# Altium schematic sheet Power.SchDot(Power)
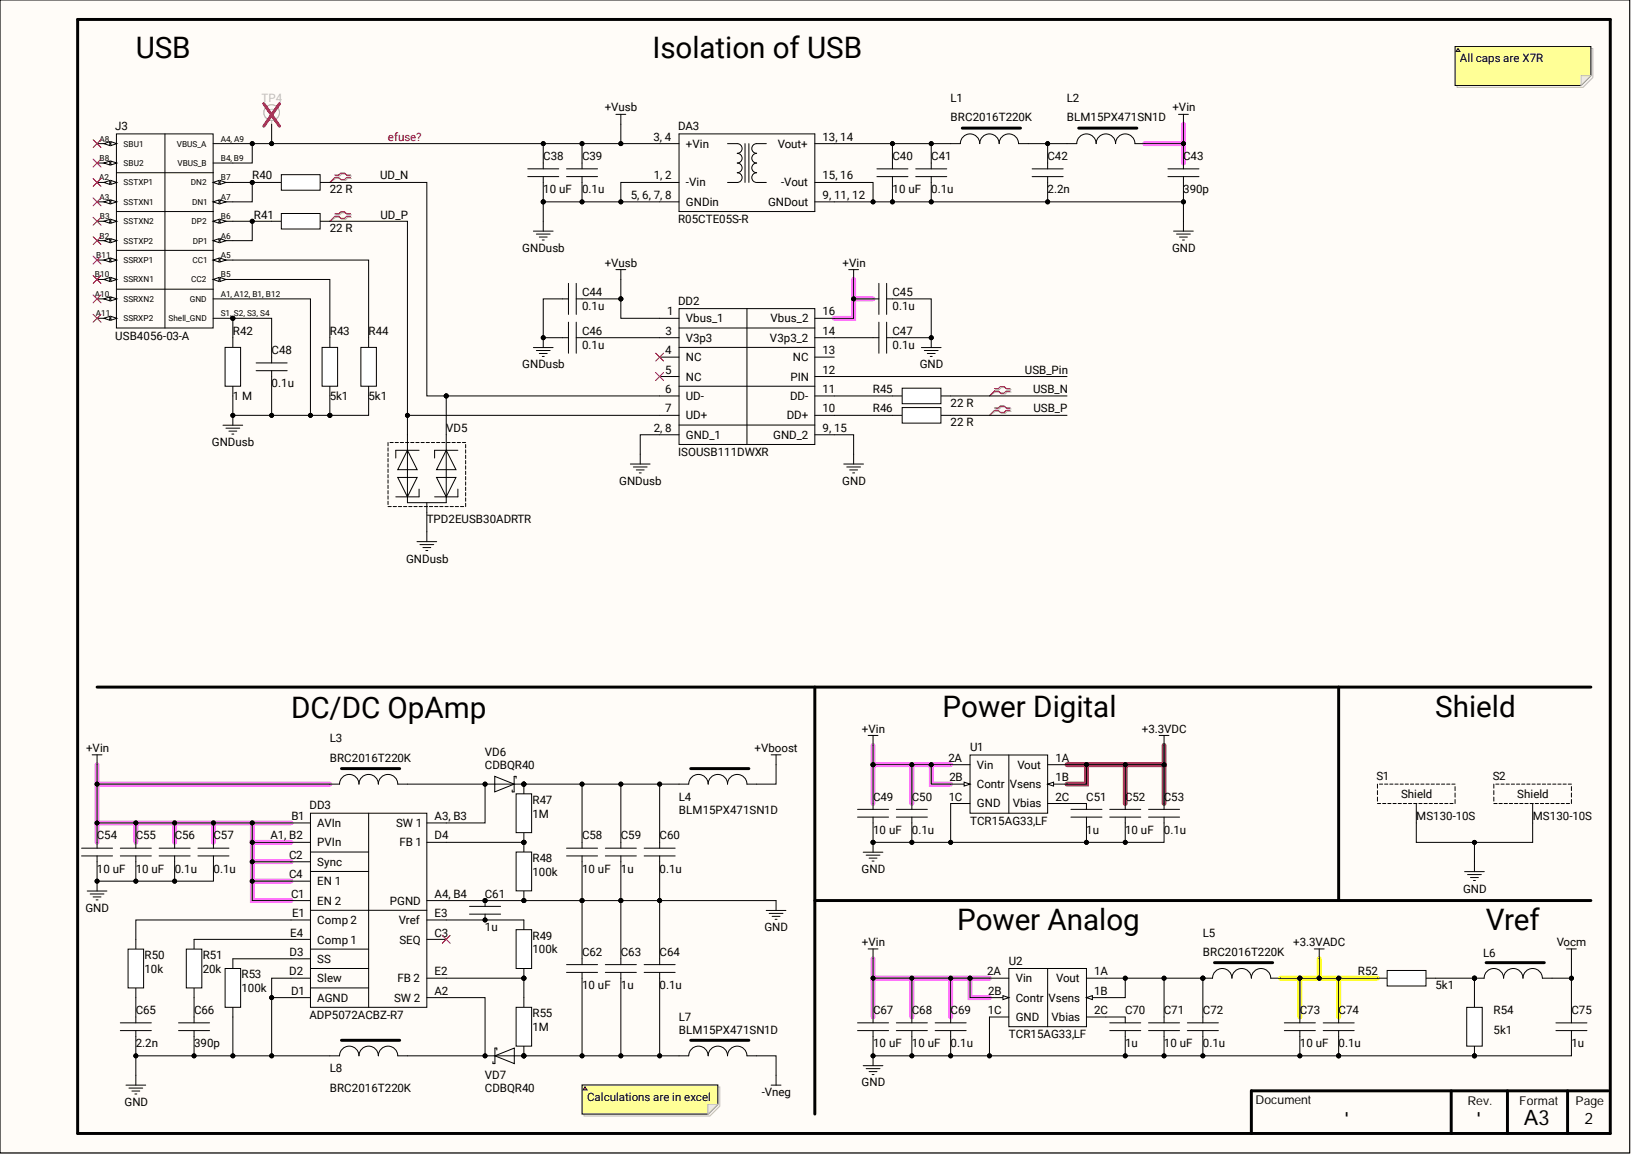
[diagram p0: Power.SchDot(Power)]

## Parts
C38  10 uF  [C0402]
C39  0.1u  [C0402]
C40  10 uF  [C0402]
C41  0.1u  [C0402]
C42  2.2n  [C0402]
C43  390p  [C0402]
C44  0.1u  [C0402]
C45  0.1u  [C0402]
C46  0.1u  [C0402]
C47  0.1u  [C0402]
C48  0.1u  [C0402]
C49  10 uF  [C0402]
C50  0.1u  [C0402]
C51  1u  [C0402]
C52  10 uF  [C0402]
C53  0.1u  [C0402]
C54  10 uF  [C0402]
C55  10 uF  [C0402]
C56  0.1u  [C0402]
C57  0.1u  [C0402]
C58  10 uF  [C0402]
C59  1u  [C0402]
C60  0.1u  [C0402]
C61  1u  [C0402]
C62  10 uF  [C0402]
C63  1u  [C0402]
C64  0.1u  [C0402]
C65  2.2n  [C0402]
C66  390p  [C0402]
C67  10 uF  [C0402]
C68  10 uF  [C0402]
C69  0.1u  [C0402]
C70  1u  [C0402]
C71  10 uF  [C0402]
C72  0.1u  [C0402]
C73  10 uF  [C0402]
C74  0.1u  [C0402]
C75  1u  [C0402]
DA3  R05CTE05S-R  [SOIC-16]
DD2  ISOUSB111DWXR  [SSOP-16]
DD3  ADP5072ACBZ-R7  [WLCSP-20]
J3  USB4056-03-A  [USB 3.2 Gen 2]
L1  BRC2016T220K  [L0603]
L2  BLM15PX471SN1D  [R0402]
L3  BRC2016T220K  [L0603]
L4  BLM15PX471SN1D  [R0402]
L5  BRC2016T220K  [L0603]
L6  BLM15PX471SN1D  [R0402]
L7  BLM15PX471SN1D  [R0402]
L8  BRC2016T220K  [L0603]
R40  22 R  [R0402]
R41  22 R  [R0402]
R42  1 M  [R0402]
R43  5k1  [R0402]
R44  5k1  [R0402]
R45  22 R  [R0402]
R46  22 R  [R0402]
R47  1M  [R0402]
R48  100k  [R0402]
R49  100k  [R0402]
R50  10k  [R0402]
R51  20k  [R0402]
R52  5k1  [R0402]
R53  100k  [R0402]
R54  5k1  [R0402]
R55  1M  [R0402]
S1  MS130-10S  [13_13_5mm]
S2  MS130-10S  [13_13_5mm]
TP4  5117  [36-5117-ND]  (value not drawn)
U1  TCR15AG33,LF  [WCSP-6F-6]
U2  TCR15AG33,LF  [WCSP-6F-6]
VD5  TPD2EUSB30ADRTR  [SOT-9X3]
VD6  CDBQR40  [0402_VD]
VD7  CDBQR40  [0402_VD]

## Nets
+3.3VADC: C73.1 C74.1 L5.2 R52.1
+3.3VDC: C52.1 C53.1 U1.1A(Vout) U1.1B(Vsens)
+Vboost: L4.2
+Vin: C43.1 C45.1 C49.1 C50.1 C54.1 C55.1 C56.1 C57.1 C67.1 C68.1 C69.1 DD2.16(Vbus_2) DD3.A1, B2(PVIn) DD3.B1(AVIn) DD3.C1(EN_2) DD3.C2(Sync) DD3.C4(EN_1) L2.2 L3.1 U1.2A(Vin) U1.2B(Contr) U2.2A(Vin) U2.2B(Contr)
+Vusb: C38.1 C39.1 C44.1 DA3.3, 4(+Vin) DD2.1(Vbus_1) J3.A4, A9(VBUS_A) J3.B4, B9(VBUS_B) TP4.1
-Vneg: L7.2
GND: C40.2 C41.2 C42.2 C43.2 C45.2 C47.1 C49.2 C50.2 C51.1 C52.2 C53.2 C54.2 C55.2 C56.2 C57.2 C58.2 C59.1 C60.2 C61.2 C62.1 C63.2 C64.1 C65.2 C66.2 C67.2 C68.2 C69.2 C70.1 C71.2 C72.2 C73.2 C74.2 C75.1 DA3.9, 11, 12(GNDout) DA3.15, 16(-Vout) DD2.9, 15(GND_2) DD3.A4, B4(PGND) DD3.D1(AGND) DD3.D2(Slew) L8.1 R48.1 R53.1 R54.2 S1.1 S2.1 U1.1C(GND) U2.1C(GND)
GNDusb: C38.2 C39.2 C44.2 C46.2 C48.2 DA3.1, 2(-Vin) DA3.5, 6, 7, 8(GNDin) DD2.2, 8(GND_1) J3.A1, A12, B1, B12(GND) R42.2 R43.2 R44.2 VD5.3
Vocm: C75.2 L6.2
UD_N: DD2.6(UD-) R40.2 VD5.1
UD_P: DD2.7(UD+) R41.2 VD5.2
USB_N: R45.2
USB_P: R46.2
USB_Pin: DD2.12(PIN)
NetC40_1: C40.1 C41.1 DA3.13, 14(Vout+) L1.1
NetC42_1: C42.1 L1.2 L2.1
NetC46_1: C46.1 DD2.3(V3p3)
NetC47_2: C47.2 DD2.14(V3p3_2)
NetC48_1: C48.1 J3.S1, S2, S3, S4(Shell_GND) R42.1
NetC51_2: C51.2 U1.2C(Vbias)
NetC58_1: C58.1 C59.2 C60.1 L4.1 R47.1 VD6.2
NetC61_1: C61.1 DD3.E3(Vref) R49.2
NetC62_2: C62.2 C63.1 C64.2 L7.1 R55.1 VD7.1
NetC65_1: C65.1 R50.1
NetC66_1: C66.1 R51.1
NetC70_2: C70.2 U2.2C(Vbias)
NetC71_1: C71.1 C72.1 L5.1 U2.1A(Vout) U2.1B(Vsens)
NetDD2_10: DD2.10(DD+) R46.1
NetDD2_11: DD2.11(DD-) R45.1
NetDD3_A2: DD3.A2(SW_2) L8.2 VD7.2
NetDD3_A3, B3: DD3.A3, B3(SW_1) L3.2 VD6.1
NetDD3_D3: DD3.D3(SS) R53.2
NetDD3_D4: DD3.D4(FB_1) R47.2 R48.2
NetDD3_E1: DD3.E1(Comp_2) R50.2
NetDD3_E2: DD3.E2(FB_2) R49.1 R55.2
NetDD3_E4: DD3.E4(Comp_1) R51.2
NetJ3_A5: J3.A5(CC1) R44.1
NetJ3_A6: J3.A6(DP1) J3.B6(DP2) R41.1
NetJ3_A7: J3.A7(DN1) J3.B7(DN2) R40.1
NetJ3_B5: J3.B5(CC2) R43.1
NetL6_1: L6.1 R52.2 R54.1

Not connected: DD2 4(NC) 5(NC) 13(NC); DD3 C3(SEQ); J3 A2(SSTXP1) A3(SSTXN1) A8(SBU1) A10(SSRXN2) A11(SSRXP2) B2(SSTXP2) B3(SSTXN2) B8(SBU2) B10(SSRXN1) B11(SSRXP1)

## Library data of the parts
C38 C40 C49 C52 C54 C55 C58 C62 C67 C68 C71 C73 = MLCC: Multilayer Ceramic Capacitors MLCC - SMD/SMT 10 uF 10 VDC 20% 0402 X5R; Manufacturer: Murata; P/N: GRM155R61A106ME11D; Case: 0402; Component Kind: Standard; Component Type: MLCC; ComponentLink1Description: Link; ComponentLink2Description: Datasheet; Value: 10 uF; Library Name: Scheet.SchLib
  C38 C40 C54 C55 C58 C62: ComponentLink1URL: https://eu.mouser.com/ProductDetail/Murata-Electronics/GRT155C81A475ME13D?qs=ufv2HEpFn%252BRTWI1%252Bv%2F3NwA%3D%3D; ComponentLink2URL: https://eu.mouser.com/datasheet/2/281/1/GRT155C81A475ME13_01A-3135426.pdf
C39 C41-C48 C50 C53 C56 C57 C60 C64-C66 C69 C72 C74 = MLCC: Multilayer Ceramic Capacitors MLCC - SMD/SMT 0.1 uF 50 VDC 20% 0402 X6S; Manufacturer: Murata; P/N: GRM155C81H104ME14D; Case: 0402; Component Kind: Standard; Component Type: MLCC; ComponentLink1Description: Link; ComponentLink2Description: Datasheet; Library Name: Scheet.SchLib
  C39 C44 C46-C48: ComponentLink1URL: https://eu.mouser.com/ProductDetail/Murata-Electronics/GRM155C81H104ME14D?qs=IPQhFcwEUOignsDjW4gFkg%3D%3D; ComponentLink2URL: https://eu.mouser.com/datasheet/2/281/1/GRM155C81H104ME14_01A-1983691.pdf; Value: 0.1u
  C41 C45 C50 C53 C56 C57 C60 C64 C69 C72 C74: Value: 0.1u
  C42 C65: Value: 2.2n
  C43 C66: Value: 390p
C51 C59 C61 C63 C70 C75 = MLCC: Multilayer Ceramic Capacitors MLCC - SMD/SMT 1 uF 10 VDC 20% 0402 X7S AEC-Q200; Manufacturer: Murata; P/N: GCM155C71A105ME38D; Case: 0402; Component Kind: Standard; Component Type: MLCC; ComponentLink1Description: Link; ComponentLink1URL: https://eu.mouser.com/ProductDetail/Murata-Electronics/GCM155C71A105ME38D?qs=QzBtWTOodeV5ddl%2FvWs3LA%3D%3D; ComponentLink2Description: Datasheet; ComponentLink2URL: https://eu.mouser.com/datasheet/2/281/1/GCM155C71A105ME38_01A-3142248.pdf; Value: 1u; Library Name: Scheet.SchLib
DA3 = R05CTE05S-R: Isolated DC/DC Converters - SMD 1W 4.5-5.5Vin 5.0Vout 200mA Reel; Manufacturer: RECOM; Value: 1 W, 5V; Case: SOIC-16; Component Kind: Standard; Component Type: Isolated DC/DC Converters - SMD; ComponentLink1Description: Datasheet; ComponentLink1URL: https://eu.mouser.com/datasheet/2/468/RxxCTExxS-3179767.pdf; ComponentLink2Description: Link; ComponentLink2URL: https://eu.mouser.com/ProductDetail/RECOM-Power/R05CTE05S-R?qs=7D1LtPJG0i0SUCKLd%252BnSSQ%3D%3D; P/N: R05CTE05S-R; Library Name: Scheet.SchLib
DD2 = ISOUSB111DWXR: USB Interface IC Low-emission isolated USB repeater supporting low and full speed 16-SSOP -40 to 125; Manufacturer: Texas Instruments; Value: USB 2.0; Case: SSOP-16; Component Kind: Standard; Component Type: USB Interface IC; ComponentLink1Description: Datasheet; ComponentLink1URL: https://www.ti.com/lit/ds/symlink/isousb111.pdf?ts=1708034758891&ref_url=https%253A%252F%252Fwww.ti.com%252Fproduct%252FISOUSB111; ComponentLink2Description: Link; ComponentLink2URL: https://eu.mouser.com/ProductDetail/Texas-Instruments/ISOUSB111DWXR?qs=Y0Uzf4wQF3msEozH7EwD3g%3D%3D; P/N: ISOUSB111DWXR; Library Name: Scheet.SchLib
DD3 = ADP5072ACBZ-R7: Switching Voltage Regulators 1 A/0.6 A DC-to-DC Switching Regulator with Independent Positive and Negative Outputs; Manufacturer: Analog Devices Inc.; Value: - 30 V to 35 V; Case: WLCSP-20; Component Kind: Standard; Component Type: Switching Voltage Regulators; ComponentLink1Description: Datasheet; ComponentLink1URL: https://eu.mouser.com/datasheet/2/609/adp5072-3121366.pdf; ComponentLink2Description: Link; ComponentLink2URL: https://eu.mouser.com/ProductDetail/Analog-Devices/ADP5072ACBZ-R7?qs=w%2Fv1CP2dgqoekXcyqSGVnQ%3D%3D; P/N: ADP5072ACBZ-R7; Library Name: Scheet.SchLib
J3 = USB4056-03-A: USB Connectors USB C 3.2 Rec, Horz, Hybrid PCB Top Mount, T+R; Manufacturer: Global Connector Technology (GCT); Value: 3.2; Case: Type C 24 pin; Component Kind: Standard; Component Type: Type C 24 pin; ComponentLink1Description: Datasheet; ComponentLink1URL: https://eu.mouser.com/datasheet/2/837/GCT_06232023_USB4056-3223375.pdf; ComponentLink2Description: Link; ComponentLink2URL: https://eu.mouser.com/ProductDetail/GCT/USB4056-03-A?qs=QNEnbhJQKvbZ7hZzgFEugA%3D%3D; P/N: USB4056-03-A; Library Name: Scheet.SchLib
L1 L3 L5 L8 = LQM18DZ470M70L: Power Inductors - SMD 0603 47uH 20% 180mA 3.32ohm AEC-Q200; Case: 0603; Component Kind: Standard; Component Type: Power Inductors - SMD; ComponentLink1Description: Datasheet; ComponentLink1URL: https://eu.mouser.com/datasheet/2/281/1/JELF243B_9128-3158890.pdf; ComponentLink2Description: Link; ComponentLink2URL: https://eu.mouser.com/ProductDetail/Murata-Electronics/LQM18DZ470M70L?qs=aP1CjGhiNiGa4GC35xm0xg%3D%3D; P/N: BRC2016T220K; Library Name: Scheet.SchLib
L2 L4 L6 L7 = BLM15PX471SN1D: Ferrite Beads 470 OHM 25% Alternate Sizing Guide Below; Manufacturer: Murata; Value: 470 Ohms, 1A; Case: 0402; Component Kind: Standard; Component Type: Ferrite Beads; ComponentLink1Description: Datasheet; ComponentLink1URL: https://eu.mouser.com/datasheet/2/281/ENFA0018-1915754.pdf; ComponentLink2Description: Link; ComponentLink2URL: https://eu.mouser.com/ProductDetail/Murata-Electronics/BLM15PX471SN1D?qs=eTNEoJdcnEJgxrnv%252BsVZMw%3D%3D; P/N: BLM15PX471SN1D; Library Name: Scheet.SchLib
R40 R41 R45 R46 = SMD Resistor: Res SMD; Manufacturer: Panasonic; P/N: ERJ-2GEJ220X; Case: 0402; Component Kind: Standard; ComponentLink1Description: Datasheet; ComponentLink2Description: Link; Value: 22 R; Library Name: Scheet.SchLib
  R40 R45: ComponentLink1URL: https://eu.mouser.com/datasheet/2/315/AOA0000C301-1488782.pdf; ComponentLink2URL: https://eu.mouser.com/ProductDetail/Panasonic/ERJ-2GEJ220X?qs=sGAEpiMZZMvdGkrng054t8ugkoYZivkgE05%252BFXPHW90%3D
R42 = SMD Resistor: Thick Film Resistors 0402 5% 1.0Mohm Anti-Sulfur AEC-Q200; Manufacturer: Panasonic; P/N: ERJ-U02J105X; Case: 0402; Component Kind: Standard; ComponentLink1Description: Datasheet; ComponentLink1URL: https://eu.mouser.com/datasheet/2/315/AOA0000C334-1314047.pdf; ComponentLink2Description: Link; ComponentLink2URL: https://eu.mouser.com/ProductDetail/Panasonic/ERJ-U02J105X?qs=sGAEpiMZZMvdGkrng054txgTq5MjvbWt4CoE%2F2ATr1A%3D; Value: 1 M; Library Name: Scheet.SchLib
R43 R44 R52 R54 = SMD Resistor: Thick Film Resistors - SMD 0402 10Kohms 0.5%AEC-Q200; Manufacturer: Panasonic; P/N: ERJ-2RHD103X; Case: 0402; Component Kind: Standard; ComponentLink1Description: Datasheet; ComponentLink1URL: https://eu.mouser.com/datasheet/2/315/AOA0000C304-1149620.pdf; ComponentLink2Description: Link; ComponentLink2URL: https://eu.mouser.com/ProductDetail/Panasonic/ERJ-2RKF2202X?qs=sGAEpiMZZMvdGkrng054t8AJgcdMkx7xGCv6QlnvaAM%3D; Value: 5k1; Library Name: Scheet.SchLib
R47 R55 = SMD Resistor: Res SMD; Component Kind: Standard; ComponentLink1Description: Datasheet; ComponentLink2Description: Link; Value: 1M; Library Name: Scheet.SchLib
R48 R49 R53 = SMD Resistor: Thick Film Resistors - SMD 0402 10Kohms 0.5%AEC-Q200; Case: 0402; Component Kind: Standard; ComponentLink1Description: Datasheet; ComponentLink2Description: Link; Value: 100k; Library Name: Scheet.SchLib
  R48: ComponentLink1URL: https://eu.mouser.com/datasheet/2/315/AOA0000C304-1149620.pdf; ComponentLink2URL: https://eu.mouser.com/ProductDetail/Panasonic/ERJ-2RKF2202X?qs=sGAEpiMZZMvdGkrng054t8AJgcdMkx7xGCv6QlnvaAM%3D
R50 R51 = SMD Resistor: Thick Film Resistors - SMD 0402 1K0 0.5%; Case: 0402; Component Kind: Standard; ComponentLink1Description: Datasheet; ComponentLink1URL: https://eu.mouser.com/datasheet/2/210/kamaya_products_RGC__english_20170424_101024-1651573.pdf; ComponentLink2Description: Link; ComponentLink2URL: https://eu.mouser.com/ProductDetail/Kamaya/RGC1-16SC1001DTH?qs=KAq9QXcE8HAz3iV8i32W5A%3D%3D; Library Name: Scheet.SchLib
  R50: Value: 10k
  R51: Value: 20k
S1 S2 = MS130-10S: EMI Gaskets, Sheets, Absorbers & Shielding 13 x 13 x 5mm One-piece Drawn-Seamless RF Shield/EMI Shield (CRS); Manufacturer: Masach Tech; Case: 13 x 13 x 5mm; Component Kind: Standard; Component Type: Shields; ComponentLink1Description: Datasheet; ComponentLink1URL: https://api.masach.com/media/catalog/product/file/MS128-10.pdf; ComponentLink2Description: Link; ComponentLink2URL: https://eu.mouser.com/ProductDetail/Masach/MS130-10S?qs=pUKx8fyJudDNyG0ZPUxt%2FA%3D%3D; P/N: MS130-10S; Value: 13 x 13 x 5mm; Library Name: Scheet.SchLib
TP4 = (no symbol name): PCB TEST POINT BLUE; Manufacturer: Keystone Electronics; Value: 36-5117; Case: THT; Component Kind: Standard; Component Type: Test point; ComponentLink1Description: Datasheet; ComponentLink1URL: https://ru.mouser.com/datasheet/2/215/5115_5119-744073.pdf; ComponentLink2Description: Link; ComponentLink2URL: https://ru.mouser.com/ProductDetail/Keystone-Electronics/5117?qs=%2Fha2pyFadujSuVybhyFc6oqj%252BXCGMBBx2uPmIz4OBqU%3D; Library Name: Others.SchLib; P/N: 5117
U1 U2 = TCR15AG33,LF: LDO Voltage Regulators LDO Linear Voltage Regulator 1.5A 3.3V; Manufacturer: Toshiba; Value: 3V3, 1.5A; Case: WCSP-6F-6; Component Kind: Standard; Component Type: LDO Voltage Regulators; ComponentLink1Description: Datasheet; ComponentLink1URL: https://eu.mouser.com/datasheet/2/408/TCR15AG33_datasheet_en_20230824-1916466.pdf; ComponentLink2Description: Link; ComponentLink2URL: https://eu.mouser.com/ProductDetail/Toshiba/TCR15AG33LF?qs=W0yvOO0ixfEdwQi51FhISQ%3D%3D; P/N: TCR15AG33,LF; Library Name: Scheet.SchLib
VD5 = TPD2EUSB30ADRTR: ESD Suppressors / TVS Diodes 2 Channel ESD/ Surge Solution; Manufacturer: Texas Instruments; Value: 2 Channel, 4.5 V; Case: SOT-9X3; Component Kind: Standard; Component Type: ESD Suppressors / TVS Diodes; ComponentLink1Description: Datasheet; ComponentLink1URL: https://www.ti.com/lit/ds/symlink/tpd2eusb30.pdf?ts=1708175608995&ref_url=https%253A%252F%252Feu.mouser.com%252F; ComponentLink2Description: Link; ComponentLink2URL: https://eu.mouser.com/ProductDetail/Texas-Instruments/TPD2EUSB30ADRTR?qs=O1HRStiETCgOfMa6oTfOlg%3D%3D; P/N: TPD2EUSB30ADRTR; Library Name: Scheet.SchLib
VD6 VD7 = CDBQR40: Schottky Diodes & Rectifiers 200mA 40V DFN Sm. Sgnl. Schottky; Manufacturer: Comchip Technology; Value: 200mA, 40V; Case: 0402; Component Kind: Standard; Component Type: Schottky Diodes & Rectifiers; ComponentLink1Description: Datasheet; ComponentLink1URL: https://eu.mouser.com/datasheet/2/80/CDBQR40_HF_RevC811666-2488328.pdf; ComponentLink2Description: Link; ComponentLink2URL: https://eu.mouser.com/ProductDetail/Comchip-Technology/CDBQR40?qs=tw%252BuQ%2FB6PO2qnzfzuJamKw%3D%3D; P/N: CDBQR40; Library Name: Scheet.SchLib
